# Altium schematic sheet Sensors_V3.0.SchDoc
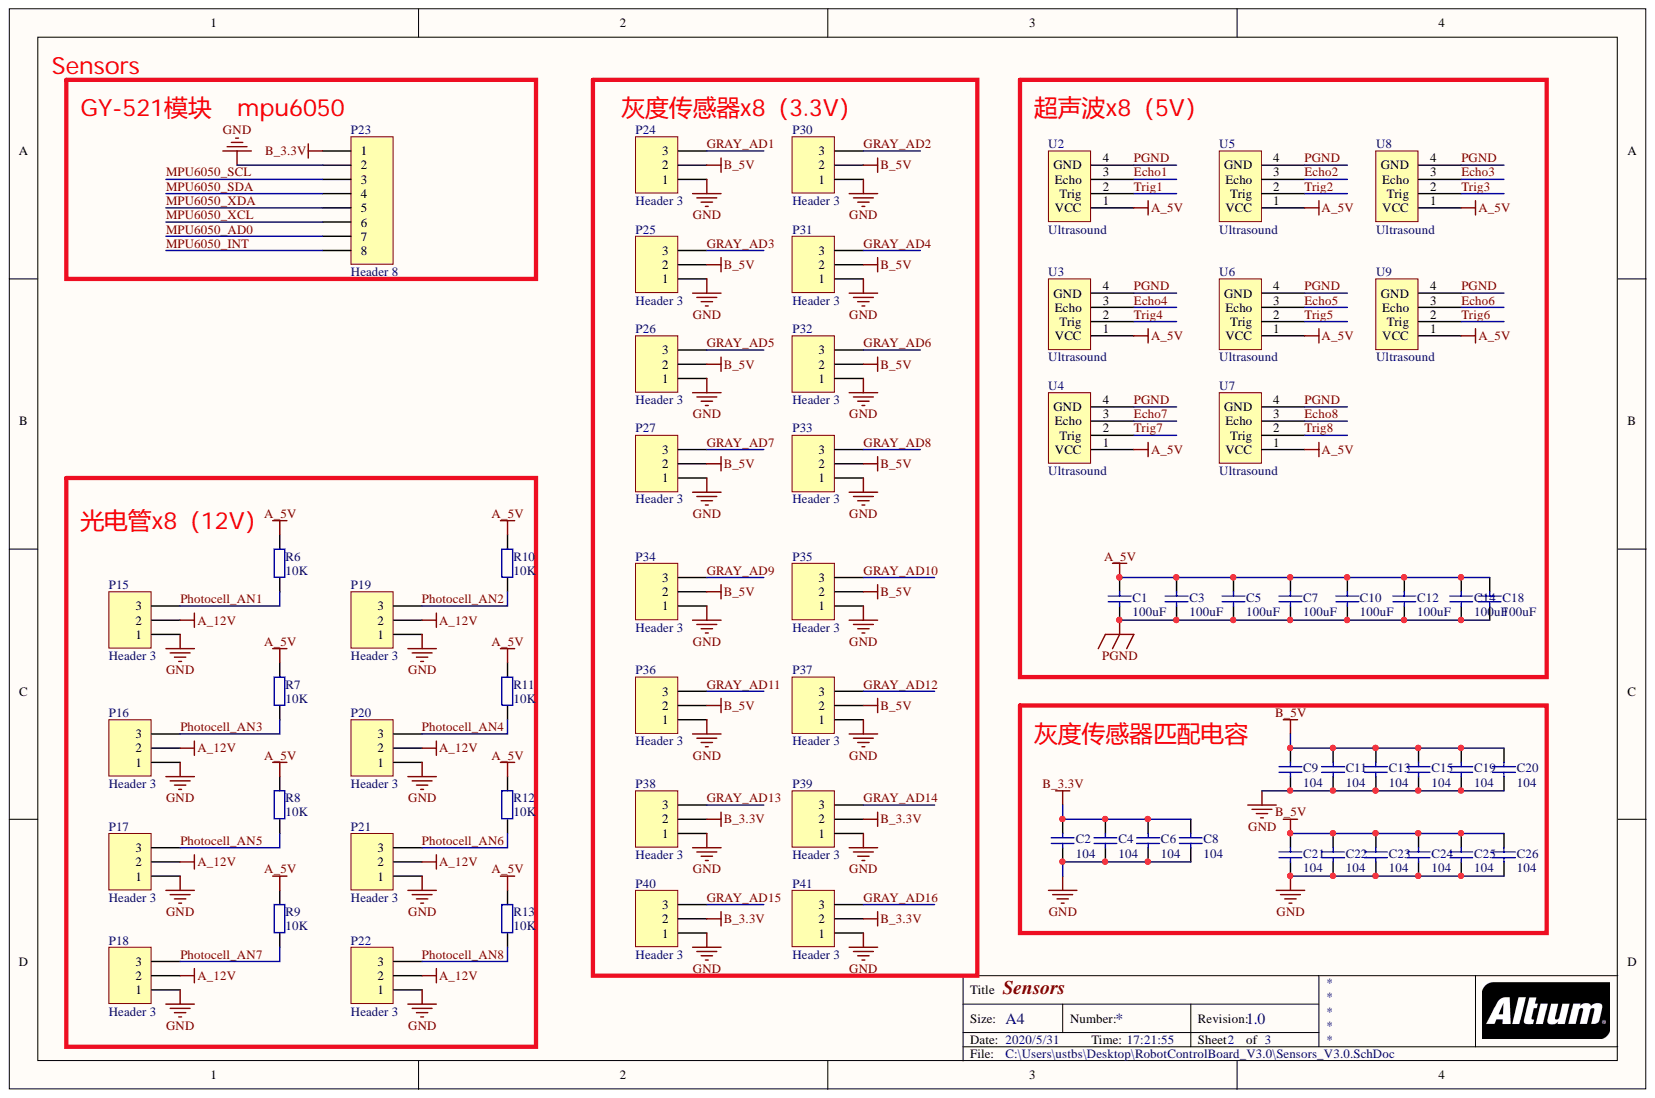
[diagram p0: Sensors_V3.0.SchDoc]

## Parts
C1  value 100uF  [C1206_1]  (hidden comment: Cap Semi)
C2  value 104  [C0603]  (hidden comment: Cap)
C3  value 100uF  [C1206_1]  (hidden comment: Cap Semi)
C4  value 104  [C0603]  (hidden comment: Cap)
C5  value 100uF  [C1206_1]  (hidden comment: Cap Semi)
C6  value 104  [C0603]  (hidden comment: Cap)
C7  value 100uF  [C1206_1]  (hidden comment: Cap Semi)
C8  value 104  [C0603]  (hidden comment: Cap)
C9  value 104  [C0603]  (hidden comment: Cap)
C10  value 100uF  [C1206_1]  (hidden comment: Cap Semi)
C11  value 104  [C0603]  (hidden comment: Cap)
C12  value 100uF  [C1206_1]  (hidden comment: Cap Semi)
C13  value 104  [C0603]  (hidden comment: Cap)
C14  value 100uF  [C1206_1]  (hidden comment: Cap Semi)
C15  value 104  [C0603]  (hidden comment: Cap)
C18  value 100uF  [C1206_1]  (hidden comment: Cap Semi)
C19  value 104  [C0603]  (hidden comment: Cap)
C20  value 104  [C0603]  (hidden comment: Cap)
C21  value 104  [C0603]  (hidden comment: Cap)
C22  value 104  [C0603]  (hidden comment: Cap)
C23  value 104  [C0603]  (hidden comment: Cap)
C24  value 104  [C0603]  (hidden comment: Cap)
C25  value 104  [C0603]  (hidden comment: Cap)
C26  value 104  [C0603]  (hidden comment: Cap)
P15  Header 3  [sip-3]
P16  Header 3  [sip-3]
P17  Header 3  [sip-3]
P18  Header 3  [sip-3]
P19  Header 3  [sip-3]
P20  Header 3  [sip-3]
P21  Header 3  [sip-3]
P22  Header 3  [sip-3]
P23  Header 8  [mpu6050]
P24  Header 3  [sip-3]
P25  Header 3  [sip-3]
P26  Header 3  [sip-3]
P27  Header 3  [sip-3]
P30  Header 3  [sip-3]
P31  Header 3  [sip-3]
P32  Header 3  [sip-3]
P33  Header 3  [sip-3]
P34  Header 3  [sip-3]
P35  Header 3  [sip-3]
P36  Header 3  [sip-3]
P37  Header 3  [sip-3]
P38  Header 3  [sip-3]
P39  Header 3  [sip-3]
P40  Header 3  [sip-3]
P41  Header 3  [sip-3]
R6  value 10K  [R0603]  (hidden comment: Res2)
R7  value 10K  [R0603]  (hidden comment: Res2)
R8  value 10K  [R0603]  (hidden comment: Res2)
R9  value 10K  [R0603]  (hidden comment: Res2)
R10  value 10K  [R0603]  (hidden comment: Res2)
R11  value 10K  [R0603]  (hidden comment: Res2)
R12  value 10K  [R0603]  (hidden comment: Res2)
R13  value 10K  [R0603]  (hidden comment: Res2)
U2  Ultrasound  [sip-4]
U3  Ultrasound  [sip-4]
U4  Ultrasound  [sip-4]
U5  Ultrasound  [sip-4]
U6  Ultrasound  [sip-4]
U7  Ultrasound  [sip-4]
U8  Ultrasound  [sip-4]
U9  Ultrasound  [sip-4]

## Nets
A_5V: C1.2 C3.2 C5.2 C7.2 C10.2 C12.2 C14.2 C18.2 R6.1 R7.1 R8.1 R9.1 R10.1 R11.1 R12.1 R13.1 U2.1(VCC) U3.1(VCC) U4.1(VCC) U5.1(VCC) U6.1(VCC) U7.1(VCC) U8.1(VCC) U9.1(VCC)
A_12V: P15.2 P16.2 P17.2 P18.2 P19.2 P20.2 P21.2 P22.2
B_3.3V: C2.2 C4.2 C6.2 C8.2 P23.1 P38.2 P39.2 P40.2 P41.2
B_5V: C9.2 C11.2 C13.2 C15.2 C19.2 C20.2 C21.2 C22.2 C23.2 C24.2 C25.2 C26.2 P24.2 P25.2 P26.2 P27.2 P30.2 P31.2 P32.2 P33.2 P34.2 P35.2 P36.2 P37.2
GND: C2.1 C4.1 C6.1 C8.1 C9.1 C11.1 C13.1 C15.1 C19.1 C20.1 C21.1 C22.1 C23.1 C24.1 C25.1 C26.1 P15.1 P16.1 P17.1 P18.1 P19.1 P20.1 P21.1 P22.1 P23.2 P24.1 P25.1 P26.1 P27.1 P30.1 P31.1 P32.1 P33.1 P34.1 P35.1 P36.1 P37.1 P38.1 P39.1 P40.1 P41.1
Echo1: U2.3(Echo)
Echo2: U5.3(Echo)
Echo3: U8.3(Echo)
Echo4: U3.3(Echo)
Echo5: U6.3(Echo)
Echo6: U9.3(Echo)
Echo7: U4.3(Echo)
Echo8: U7.3(Echo)
GRAY_AD1: P24.3
GRAY_AD2: P30.3
GRAY_AD3: P25.3
GRAY_AD4: P31.3
GRAY_AD5: P26.3
GRAY_AD6: P32.3
GRAY_AD7: P27.3
GRAY_AD8: P33.3
GRAY_AD9: P34.3
GRAY_AD10: P35.3
GRAY_AD11: P36.3
GRAY_AD12: P37.3
GRAY_AD13: P38.3
GRAY_AD14: P39.3
GRAY_AD15: P40.3
GRAY_AD16: P41.3
MPU6050_AD0: P23.7
MPU6050_INT: P23.8
MPU6050_SCL: P23.3
MPU6050_SDA: P23.4
MPU6050_XCL: P23.6
MPU6050_XDA: P23.5
PGND: C1.1 C3.1 C5.1 C7.1 C10.1 C12.1 C14.1 C18.1 U2.4(GND) U3.4(GND) U4.4(GND) U5.4(GND) U6.4(GND) U7.4(GND) U8.4(GND) U9.4(GND)
Photocell_AN1: P15.3 R6.2
Photocell_AN2: P19.3 R10.2
Photocell_AN3: P16.3 R7.2
Photocell_AN4: P20.3 R11.2
Photocell_AN5: P17.3 R8.2
Photocell_AN6: P21.3 R12.2
Photocell_AN7: P18.3 R9.2
Photocell_AN8: P22.3 R13.2
Trig1: U2.2(Trig)
Trig2: U5.2(Trig)
Trig3: U8.2(Trig)
Trig4: U3.2(Trig)
Trig5: U6.2(Trig)
Trig6: U9.2(Trig)
Trig7: U4.2(Trig)
Trig8: U7.2(Trig)

## Library data of the parts
C1 C3 C5 C7 C10 C12 C14 C18 = Cap Semi: Capacitor (Semiconductor SIM Model); Component Kind: Standard; LatestRevisionDate: 29-May-2009; LatestRevisionNote: IPC-7351 Footprint Added.; Library Name: L_AS1015_1.0_1.SCHLIB; PackageDescription: Chip Capacitor; PackageReference: C1206; Published: 8-Jun-2000; Signal Integrity: Cap Semi; Simulation: CAP; Publisher: Altium Limited
C2 C4 C6 C8 C9 C11 C13 C15 C19-C26 = Cap: Capacitor; Component Kind: Standard; LatestRevisionDate: 17-Jul-2002; LatestRevisionNote: Re-released for DXP Platform.; Library Name: Miscellaneous Devices.IntLib; PackageDescription: Radial Cap, Thru-Hole; 2 Leads; 0.3 in Pin Spacing; PackageReference: RAD-0.3; Published: 8-Jun-2000; Signal Integrity: Cap; Simulation: CAP; Publisher: Altium Limited
P15-P22 P24-P27 P30-P41 = Header 3: Header, 3-Pin; Component Kind: Standard; LatestRevisionDate: 17-Jul-2002; LatestRevisionNote: Re-released for DXP Platform.; Library Name: Miscellaneous Connectors.IntLib; Signal Integrity: Connector; Publisher: Altium Limited
P23 = Header 8: Header, 8-Pin; Component Kind: Standard; LatestRevisionDate: 17-Jul-2002; LatestRevisionNote: Re-released for DXP Platform.; Library Name: Miscellaneous Connectors.IntLib; Signal Integrity: Connector; Publisher: Altium Limited
R6-R13 = Res2: Resistor; Component Kind: Standard; LatestRevisionDate: 17-Jul-2002; LatestRevisionNote: Re-released for DXP Platform.; Library Name: Free Documents.IntLib; PackageReference: AXIAL-0.4; Published: 8-Jun-2000; Signal Integrity: Res; Simulation: RESISTOR; Publisher: Altium Limited
U2-U9 = Ultrasound: Component Kind: Standard; Description: Ultrasound; Library Name: Schlib1.SchLib
